# Altium schematic sheet Connectors_ Front_of_Board.SchDoc
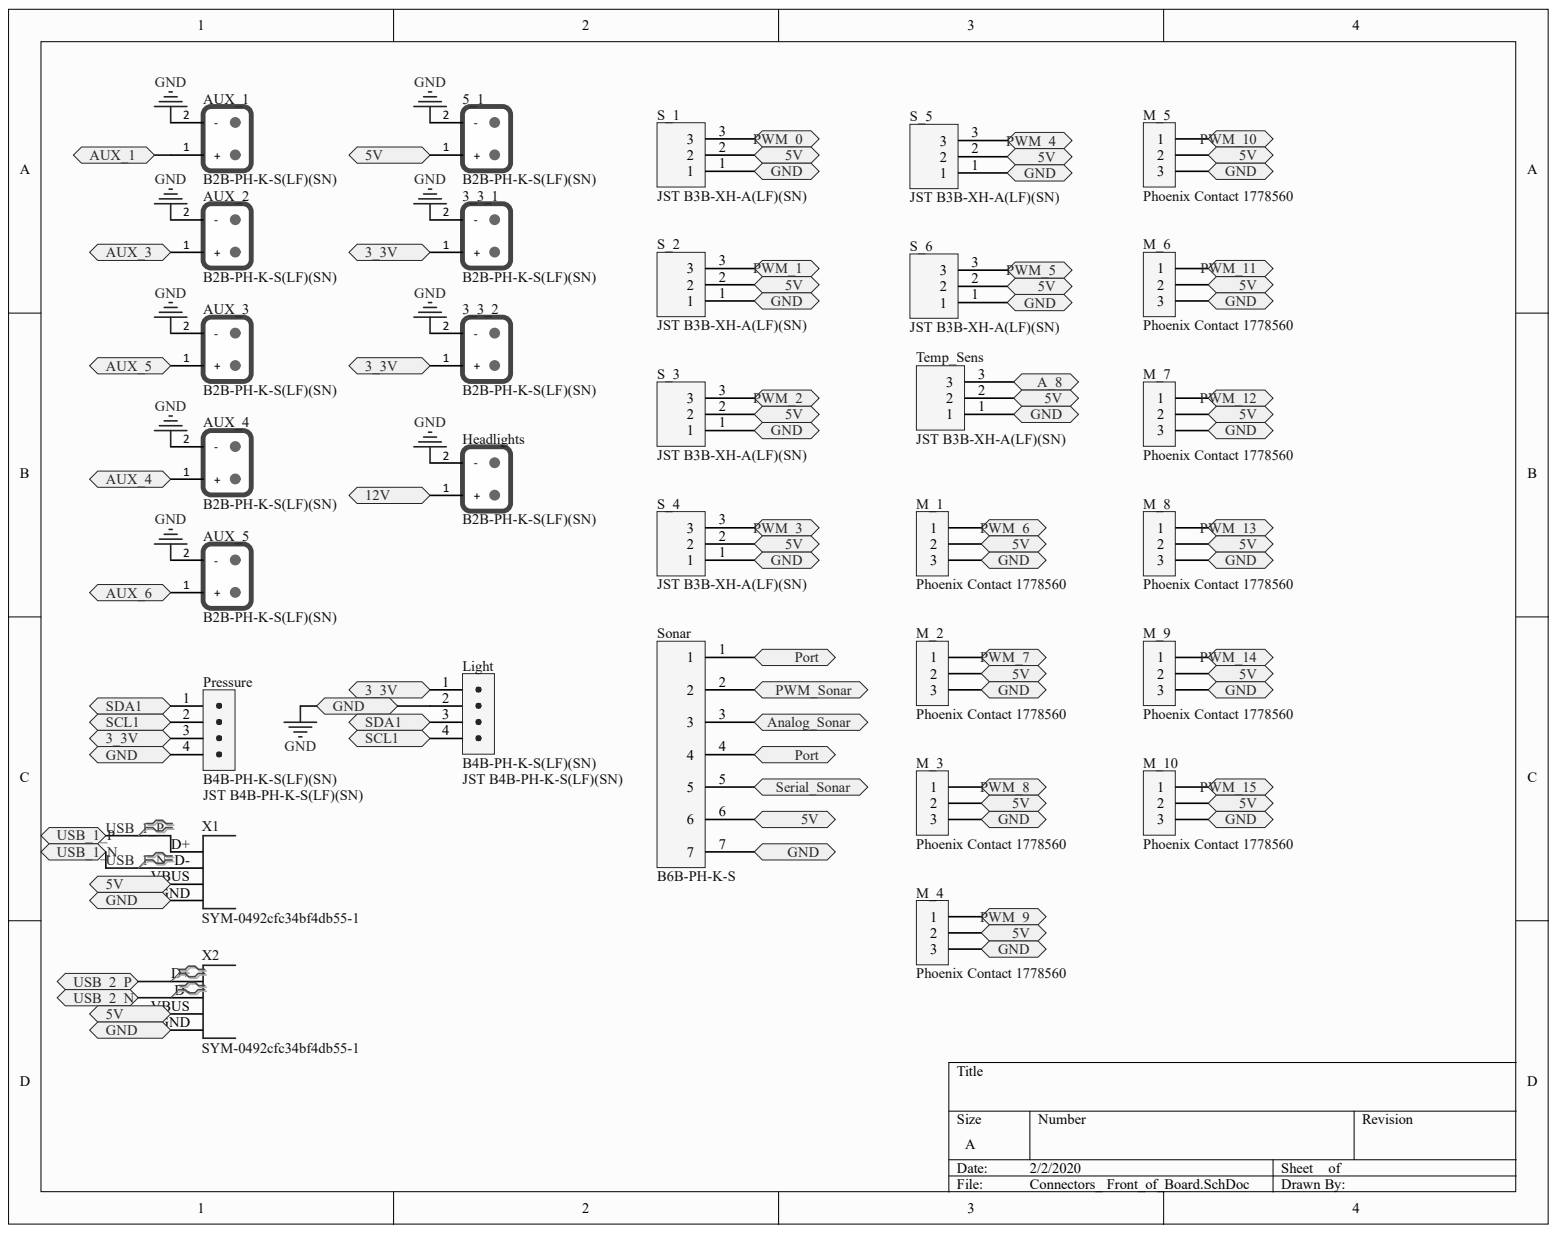
[diagram p0: Connectors_ Front_of_Board.SchDoc]

## Parts
3_3_1  B2B-PH-K-S(LF)(SN)  [B2B-PH-K-S]
3_3_2  B2B-PH-K-S(LF)(SN)  [B2B-PH-K-S]
5_1  B2B-PH-K-S(LF)(SN)  [B2B-PH-K-S]
AUX_1  B2B-PH-K-S(LF)(SN)  [B2B-PH-K-S]
AUX_2  B2B-PH-K-S(LF)(SN)  [B2B-PH-K-S]
AUX_3  B2B-PH-K-S(LF)(SN)  [B2B-PH-K-S]
AUX_4  B2B-PH-K-S(LF)(SN)  [B2B-PH-K-S]
AUX_5  B2B-PH-K-S(LF)(SN)  [B2B-PH-K-S]
Headlights  B2B-PH-K-S(LF)(SN)  [B2B-PH-K-S]
Light  B4B-PH-K-S(LF)(SN)  Manufacturer: JST  Manufacturer Part Number: JST B4B-PH-K-S(LF)(SN)  [PCB-nfo1v6t1kar7doke0b73-1]
M_1  Phoenix Contact 1778560  [PCB-vmh7842dxbg4go5v6ve5-1]
M_2  Phoenix Contact 1778560  [PCB-vmh7842dxbg4go5v6ve5-1]
M_3  Phoenix Contact 1778560  [PCB-vmh7842dxbg4go5v6ve5-1]
M_4  Phoenix Contact 1778560  [PCB-vmh7842dxbg4go5v6ve5-1]
M_5  Phoenix Contact 1778560  [PCB-vmh7842dxbg4go5v6ve5-1]
M_6  Phoenix Contact 1778560  [PCB-vmh7842dxbg4go5v6ve5-1]
M_7  Phoenix Contact 1778560  [PCB-vmh7842dxbg4go5v6ve5-1]
M_8  Phoenix Contact 1778560  [PCB-vmh7842dxbg4go5v6ve5-1]
M_9  Phoenix Contact 1778560  [PCB-vmh7842dxbg4go5v6ve5-1]
M_10  Phoenix Contact 1778560  [PCB-vmh7842dxbg4go5v6ve5-1]
Pressure  B4B-PH-K-S(LF)(SN)  Manufacturer: JST  Manufacturer Part Number: JST B4B-PH-K-S(LF)(SN)  [PCB-nfo1v6t1kar7doke0b73-1]
S_1  JST B3B-XH-A(LF)(SN)  [PCB-xjwvd9aqo2gjqkgbq7n2-1]
S_2  JST B3B-XH-A(LF)(SN)  [PCB-xjwvd9aqo2gjqkgbq7n2-1]
S_3  JST B3B-XH-A(LF)(SN)  [PCB-xjwvd9aqo2gjqkgbq7n2-1]
S_4  JST B3B-XH-A(LF)(SN)  [PCB-xjwvd9aqo2gjqkgbq7n2-1]
S_5  JST B3B-XH-A(LF)(SN)  [PCB-xjwvd9aqo2gjqkgbq7n2-1]
S_6  JST B3B-XH-A(LF)(SN)  [PCB-xjwvd9aqo2gjqkgbq7n2-1]
Sonar  B6B-PH-K-S  [PCB-5xtqpwx7u0homgs4st3y-1]
Temp_Sens  JST B3B-XH-A(LF)(SN)  [PCB-xjwvd9aqo2gjqkgbq7n2-1]
X1  SYM-0492cfc34bf4db55-1  [PCB-7ltgtw0odfmj6jj62t1l-1]
X2  SYM-0492cfc34bf4db55-1  [PCB-7ltgtw0odfmj6jj62t1l-1]

## Ports
3_3V, 5V, 12V, A_8, ANALOG_SONAR, AUX_1, AUX_3, AUX_4, AUX_5, AUX_6, GND, PORT, PWM_0, PWM_1, PWM_2, PWM_3, PWM_4, PWM_5, PWM_6, PWM_7, PWM_8, PWM_9, PWM_10, PWM_11, PWM_12, PWM_13, PWM_14, PWM_15, PWM_SONAR, SCL1, SDA1, SERIAL_SONAR, USB_1_N, USB_1_P, USB_2_N, USB_2_P

## Nets
ANALOG_SONAR: Sonar.3 ; port ANALOG_SONAR
PORT: Sonar.1 Sonar.4 ; port PORT
PWM_SONAR: Sonar.2 ; port PWM_SONAR
SERIAL_SONAR: Sonar.5 ; port SERIAL_SONAR
3_3V: 3_3_1.1 3_3_2.1 Light.1 Pressure.3 ; port 3_3V
5V: 5_1.1 M_1.2 M_2.2 M_3.2 M_4.2 M_5.2 M_6.2 M_7.2 M_8.2 M_9.2 M_10.2 S_1.2 S_2.2 S_3.2 S_4.2 S_5.2 S_6.2 Sonar.6 Temp_Sens.2 X1.VBUS X2.VBUS ; port 5V
12V: Headlights.1 ; port 12V
A_8: Temp_Sens.3 ; port A_8
AUX_1: AUX_1.1 ; port AUX_1
AUX_3: AUX_2.1 ; port AUX_3
AUX_4: AUX_4.1 ; port AUX_4
AUX_5: AUX_3.1 ; port AUX_5
AUX_6: AUX_5.1 ; port AUX_6
GND: 3_3_1.2 3_3_2.2 5_1.2 AUX_1.2 AUX_2.2 AUX_3.2 AUX_4.2 AUX_5.2 Headlights.2 Light.2 M_1.3 M_2.3 M_3.3 M_4.3 M_5.3 M_6.3 M_7.3 M_8.3 M_9.3 M_10.3 Pressure.4 S_1.1 S_2.1 S_3.1 S_4.1 S_5.1 S_6.1 Sonar.7 Temp_Sens.1 X1.GND X2.GND ; port GND
PWM_0: S_1.3 ; port PWM_0
PWM_1: S_2.3 ; port PWM_1
PWM_2: S_3.3 ; port PWM_2
PWM_3: S_4.3 ; port PWM_3
PWM_4: S_5.3 ; port PWM_4
PWM_5: S_6.3 ; port PWM_5
PWM_6: M_1.1 ; port PWM_6
PWM_7: M_2.1 ; port PWM_7
PWM_8: M_3.1 ; port PWM_8
PWM_9: M_4.1 ; port PWM_9
PWM_10: M_5.1 ; port PWM_10
PWM_11: M_6.1 ; port PWM_11
PWM_12: M_7.1 ; port PWM_12
PWM_13: M_8.1 ; port PWM_13
PWM_14: M_9.1 ; port PWM_14
PWM_15: M_10.1 ; port PWM_15
SCL1: Light.4 Pressure.2 ; port SCL1
SDA1: Light.3 Pressure.1 ; port SDA1
USB_1_N: X1.D- ; port USB_1_N
USB_1_P: X1.D+ ; port USB_1_P
USB_2_N: X2.D- ; port USB_2_N
USB_2_P: X2.D+ ; port USB_2_P

## Library data of the parts
3_3_1 3_3_2 5_1 AUX_1-AUX_5 Headlights = CMP-642947-19: CONN HEADER VERT 2POS 2MM; Manufacturer Name: JST; CiivaID: 642947; Component Kind: Standard; Library Name: CommunityVault; Manufacturer Part Number: B2B-PH-K-S(LF)(SN); Octopart URL: https://octopart.com/b2b-ph-k-s%28lf%29%28sn%29-jst-248868
Light Pressure = CMP-642981-21: PH Series 4 Position 2 mm Pitch Through Hole Crimp Top Entry Shrouded Header; Component Kind: Standard; Library Name: CommunityVault
M_1-M_10 = CMP-b6a67e9c559f6961-2: Conn Shrouded Header HDR 3 POS 2.5mm Solder ST Thru-Hole T/R; Lead-Free Status: Lead Free; Component Kind: Standard; Library Name: CommunityVault; Manufacturer Part Number: 1778560; OctopartID: b6a67e9c559f6961; Octopart URL: http://octopart.com/1778560-phoenix+contact-19973727; RoHS: Compliant
S_1-S_6 Temp_Sens = CMP-c14f087906801e88-1: XH Series 3 Position 2.5 mm Pitch Through Hole Crimp Top Entry Shrouded Header; Contact Resistance: 10.0 mΩ; Lead-Free Status: Lead Free; Component Kind: Standard; Contact Plating: Tin, Tin Lead; Library Name: CommunityVault; Mounting Style: Through Hole, Vertical
Sonar = CMP-0bb9c2b0e2f6d47e-1: JST B7B-PH-K-S (LF)(SN) Multi-pin Connector, Straight Series PH Number of pins: 7 Nominal current: 2 A; Voltage Rating (AC): 100 V; Component Kind: Standard; Contact Plating: Tin; Library Name: CommunityVault; Manufacturer: JST; Size-Width: 4.50 mm
X1 X2 = CMP-0492cfc34bf4db55-7: Right Angle, Through Hole Mount Version2.0 Type A Mini USB Connector Receptacle; Manufacturer Part Number: 292303-4; ELV Compliant: Compliant; Gender: Female; Lead-Free Status: Lead Free; Number of Contacts: 4; Component Kind: Standard; Contact Material: Copper Alloy; Contact Plating: Gold Flash; Flammability Rating: UL 94 V-0; Housing Color: Black; Housing Material: Thermoplastic; Library Name: CommunityVault; Lifecycle Status: Active; Mounting Style: Through Hole, Horizontal; Number of Positions: 4; OctopartID: 0492cfc34bf4db55; Octopart URL: http://octopart.com/292303-4-te+connectivity+%2F+amp-39545344; Packaging: Tube; REACH SVHC Compliance: No SVHC; RoHS: Compliant; Size-Height: 7.01 mm; Size-Length: 14.0 mm; Size-Width: 13.1 mm
